# Altium schematic sheet [5] POWER.SchDoc("[5] POWER")
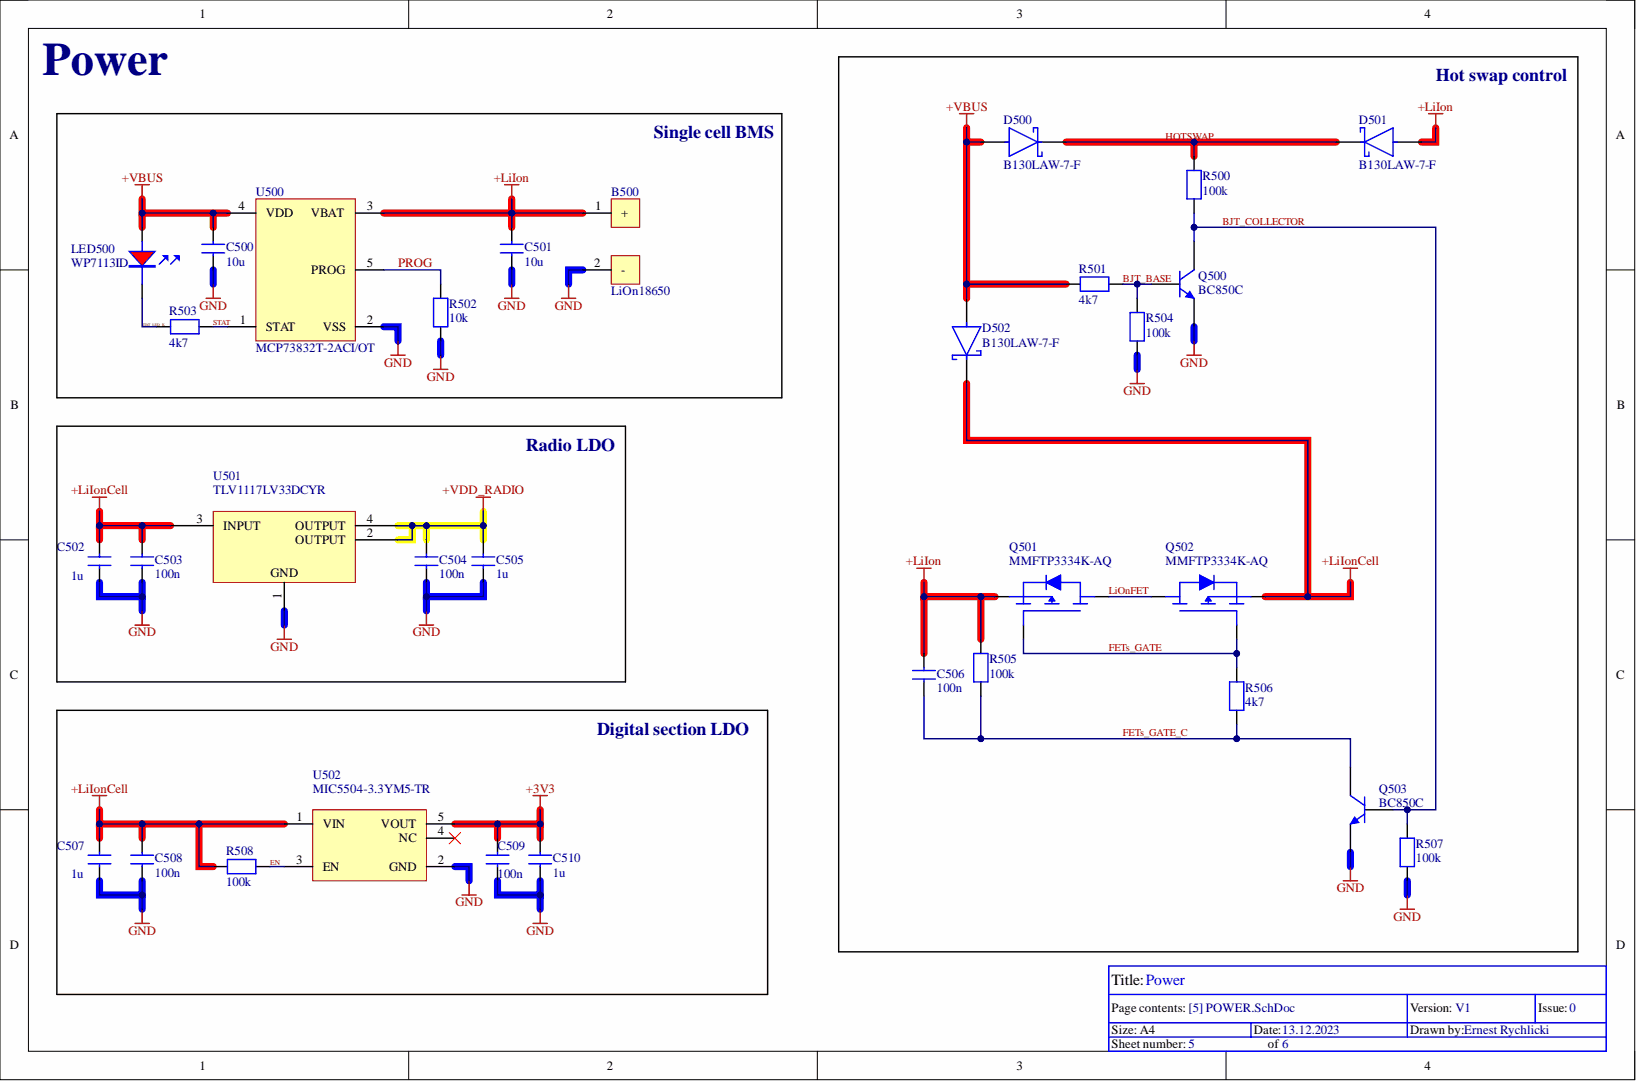
[diagram p0: [5] POWER.SchDoc]

## Parts
B500  LiOn18650  [LION18650]  (no BOM)
C500  10u  [C0402]
C501  10u  [C0402]
C502  1u  [C0402]
C503  100n  [C0402]
C504  100n  [C0402]
C505  1u  [C0402]
C506  100n  [C0402]
C507  1u  [C0402]
C508  100n  [C0402]
C509  100n  [C0402]
C510  1u  [C0402]
D500  B130LAW-7-F  [SOD-123]
D501  B130LAW-7-F  [SOD-123]
D502  B130LAW-7-F  [SOD-123]
LED500  WP7113ID  [LED5mm]
Q500  BC850C  [SOT23]
Q501  MMFTP3334K-AQ  [SOT23]
Q502  MMFTP3334K-AQ  [SOT23]
Q503  BC850C  [SOT23]
R500  100k  [R0603]
R501  4k7  [R0603]
R502  10k  [R0603]
R503  4k7  [R0603]
R504  100k  [R0603]
R505  100k  [R0603]
R506  4k7  [R0603]
R507  100k  [R0603]
R508  100k  [R0603]
U500  MCP73832T-2ACI/OT  [SOT23-5]
U501  TLV1117LV33DCYR  [SOT223-4]
U502  MIC5504-3.3YM5-TR  [SOT23-5]

## Nets
+3V3: C509.2 C510.2 U502.5(VOUT)
+LiIon: B500.1 C501.2 C506.2 D501.2 Q501.2 R505.2 U500.3(VBAT)
+LiIonCell: C502.2 C503.2 C507.2 C508.2 D502.1 Q502.2 R508.2 U501.3(INPUT) U502.1(VIN)
+VBUS: C500.2 D500.2 D502.2 LED500.A R501.2 U500.4(VDD)
+VDD_RADIO: C504.2 C505.2 U501.2(OUTPUT) U501.4(OUTPUT)
GND: B500.2 C500.1 C501.1 C502.1 C503.1 C504.1 C505.1 C507.1 C508.1 C509.1 C510.1 Q500.2 Q503.2 R502.1 R504.1 R507.1 U500.2(VSS) U501.1 U502.2(GND)
BJT_BASE: Q500.1 R501.1 R504.2
BJT_COLLECTOR: Q500.3 Q503.1 R500.1 R507.2
EN: R508.1 U502.3(EN)
FETs_GATE: Q501.1 Q502.1 R506.1
FETs_GATE_C: C506.1 Q503.3 R505.1 R506.2
HOTSWAP: D500.1 D501.1 R500.2
LiOnFET: Q501.3 Q502.3
PROG: R502.2 U500.5(PROG)
STAT: R503.1 U500.1(STAT)
STAT_LED_K: LED500.K R503.2

Not connected: U502 4(NC)

## Library data of the parts
B500 = LiOn18650: LiOn18650 - single cell battery, soldered to PCB; Library Name: ER_SCH_LIB.SchLib
C500 C501 = GRJ155R60J106ME11D: Capacitor Ceramic Mlcc 0402 10UF 6.3V SMD; Manufacturer: Murata; Tolerance: 20%; Case Code (Imperial): 0402; Case Code (Metric): 1005; Case/Package: 0402; Category: Ceramic Capacitors; Component Kind: Standard; ComponentLink1Description: https://datasheet.octopart.com/GRJ155R60J106ME11D-Murata-datasheet-149522788.pdf?src-supplier=Newark; ComponentLink1URL: https://datasheet.octopart.com/GRJ155R60J106ME11D-Murata-datasheet-149522788.pdf?src-supplier=Newark; ComponentLink2Description: http://psearch.en.murata.com/capacitor/product/GRJ155R60J106ME11%23.pdf?src-supplier=Digi-Key; ComponentLink2URL: http://psearch.en.murata.com/capacitor/product/GRJ155R60J106ME11%23.pdf?src-supplier=Digi-Key; ComponentLink3Description: https://datasheet.octopart.com/GRJ155R60J106ME11D-Murata-datasheet-165579666.pdf?src-supplier=TME; ComponentLink3URL: https://datasheet.octopart.com/GRJ155R60J106ME11D-Murata-datasheet-165579666.pdf?src-supplier=TME; Features: Soft Termination; Length: 0.039inch; Library Name: ER_SCH_LIB.SchLib; Manufacturer Part Number: GRJ155R60J106ME11D; Max Operating Temperature: 85°C; Min Operating Temperature: -55°C; Mounting Technology: Surface Mount; Packaging: Cut Tape; Pricing: 10000=0,0311, 20000=0,0295, 30000=0,0278, 50000=0,0262, 100000=0,0221, 250000=0,0204 (USD); RoHS: Yes; RoHS Compliant: Yes; Series: GRJ; Stock: 10000; Supplier: Arrow Electronics; Supplier Part Number: GRJ155R60J106ME11D; Thickness: 0.028inch; Value: 10uF; Voltage Rating: 6.3V; Width: 0.02inch
C502 C505 C507 C510 = 0402X105K100CT: Multilayer Ceramic Capacitor, 1 uF, 10%, X5r, 10 V, 0402 [1005 Metric]; Manufacturer: Walsin Technologies; Category: Ceramic Capacitors; Component Kind: Standard; ComponentLink1Description: http://www.farnell.com/datasheets/2340522.pdf?src-supplier=Farnell; ComponentLink1URL: http://www.farnell.com/datasheets/2340522.pdf?src-supplier=Farnell; ComponentLink2Description: http://www.farnell.com/datasheets/1958513.pdf?src-supplier=Farnell; ComponentLink2URL: http://www.farnell.com/datasheets/1958513.pdf?src-supplier=Farnell; ComponentLink3Description: http://www.farnell.com/datasheets/1958513.pdf?src-supplier=Element14; ComponentLink3URL: http://www.farnell.com/datasheets/1958513.pdf?src-supplier=Element14; ComponentLink4Description: https://datasheet.octopart.com/0402X105K100CT-Walsin-Technologies-datasheet-128065749.pdf?src-supplier=Future+Electronics; ComponentLink4URL: https://datasheet.octopart.com/0402X105K100CT-Walsin-Technologies-datasheet-128065749.pdf?src-supplier=Future+Electronics; ComponentLink5Description: https://datasheet.octopart.com/0402X105K100CT-Walsin-Technologies-datasheet-62066062.pdf?src-supplier=TME; ComponentLink5URL: https://datasheet.octopart.com/0402X105K100CT-Walsin-Technologies-datasheet-62066062.pdf?src-supplier=TME; ComponentLink6Description: https://datasheet.octopart.com/0402X105K100CT-Walsin-Technologies-datasheet-136037192.pdf?src-supplier=TECHDesign; ComponentLink6URL: https://datasheet.octopart.com/0402X105K100CT-Walsin-Technologies-datasheet-136037192.pdf?src-supplier=TECHDesign; Library Name: ER_SCH_LIB.SchLib; Manufacturer Part Number: 0402X105K100CT; Pricing: 10000=0,0037, 20000=0,0027, 50000=0,0026, 100000=0,0025, 250000=0,0023 (USD); RoHS: Yes; Stock: 760000; Supplier: Arrow Electronics; Supplier Part Number: 0402X105K100CT
C503 C504 C506 C508 C509 = 04023C104KAT2A: Multilayer Ceramic Capacitor, 0.1 uF, 25 V, ± 10%, X7R, 0402 [1005 Metric]; Manufacturer: Kyocera AVX; Material: 4241ConductorMaterial; Value: 100nF; Voltage: 25V; Case Code (Imperial): 0402; Case Code (Metric): 1005; Case/Package: 0402; Category: Ceramic Capacitors; Component Kind: Standard; ComponentLink1Description: https://datasheet.ciiva.com/6265/cx7r-6265272.pdf?src-supplier=Digi-Key; ComponentLink1URL: https://datasheet.ciiva.com/6265/cx7r-6265272.pdf?src-supplier=Digi-Key; ComponentLink2Description: https://datasheet.ciiva.com/1123/1679506-1123344.pdf?src-supplier=Element14; ComponentLink2URL: https://datasheet.ciiva.com/1123/1679506-1123344.pdf?src-supplier=Element14; ComponentLink3Description: https://datasheet.ciiva.com/7709/1679506-7709527.pdf?src-supplier=Element14; ComponentLink3URL: https://datasheet.ciiva.com/7709/1679506-7709527.pdf?src-supplier=Element14; ComponentLink4Description: https://datasheet.ciiva.com/14906/cx7r-14906223.pdf?src-supplier=Digi-Key; ComponentLink4URL: https://datasheet.ciiva.com/14906/cx7r-14906223.pdf?src-supplier=Digi-Key; ComponentLink5Description: https://datasheet.ciiva.com/7614/cx7r-7614663.pdf?src-supplier=Digi-Key; ComponentLink5URL: https://datasheet.ciiva.com/7614/cx7r-7614663.pdf?src-supplier=Digi-Key; Dielectric: X7R; Lead Free: Lead Free; Library Name: ER_SCH_LIB.SchLib; Manufacturer Part Number: 04023C104KAT2A; Max Operating Temperature: 125°C; Min Operating Temperature: -55°C; Mounting Technology: Surface Mount; Packaging: Cut Tape; Pins: 2; Pricing: 10000=0,0064, 20000=0,0061, 30000=0,0058, 50000=0,0052, 100000=0,0042, 150000=0,0038 (USD); Reach SVHC Compliant: No; RoHS: Yes; RoHS Compliant: Yes; Series: -; Stock: 5700000; Supplier: Arrow Electronics; Supplier Part Number: 04023C104KAT2A; Tolerance: 10%; Voltage Rating: 25V; Voltage Rating (DC): 25V; Width: 1mm
D500-D502 = B130LAW-7-F: Rectifier Diode Schottky 30V 1A 2-Pin SOD-123 T/R / DIODE SCHOTTKY 30V 1A SOD123; Manufacturer: Diodes; Forward Voltage: 420mV; Max Forward Surge Current (Ifsm): 12A; Max Reverse Current: 1mA; Polarity: Standard; Value: 40pF; Average Rectified Current: 1A; Category: Rectifier Diodes; Component Kind: Standard; ComponentLink1Description: https://datasheet.ciiva.com/913/ds30308-913553.pdf?src-supplier=Digi-Key; ComponentLink1URL: https://datasheet.ciiva.com/913/ds30308-913553.pdf?src-supplier=Digi-Key; ComponentLink2Description: https://datasheet.ciiva.com/34302/1538689-34302354.pdf?src-supplier=Jameco; ComponentLink2URL: https://datasheet.ciiva.com/34302/1538689-34302354.pdf?src-supplier=Jameco; ComponentLink3Description: https://datasheet.ciiva.com/4715/ds30308-79347-4715540.pdf?src-supplier=Mouser; ComponentLink3URL: https://datasheet.ciiva.com/4715/ds30308-79347-4715540.pdf?src-supplier=Mouser; ComponentLink4Description: https://datasheet.ciiva.com/1177/ds30308-1177411.pdf?src-supplier=Element14; ComponentLink4URL: https://datasheet.ciiva.com/1177/ds30308-1177411.pdf?src-supplier=Element14; ComponentLink5Description: https://datasheet.ciiva.com/22695/887879-22695783.pdf?src-supplier=Element14; ComponentLink5URL: https://datasheet.ciiva.com/22695/887879-22695783.pdf?src-supplier=Element14; ComponentLink6Description: https://datasheet.ciiva.com/8300/ds30308-8300503.pdf?src-supplier=Avnet; ComponentLink6URL: https://datasheet.ciiva.com/8300/ds30308-8300503.pdf?src-supplier=Avnet; ComponentLink7Description: https://datasheet.ciiva.com/8303/ds30308-8303245.pdf?src-supplier=Avnet; ComponentLink7URL: https://datasheet.ciiva.com/8303/ds30308-8303245.pdf?src-supplier=Avnet; ComponentLink8Description: https://datasheet.ciiva.com/913/ds30308-913554.pdf?src-supplier=Digi-Key; ComponentLink8URL: https://datasheet.ciiva.com/913/ds30308-913554.pdf?src-supplier=Digi-Key; ComponentLink9Description: https://datasheet.ciiva.com/913/ds30308-913555.pdf?src-supplier=Digi-Key; ComponentLink9URL: https://datasheet.ciiva.com/913/ds30308-913555.pdf?src-supplier=Digi-Key; Contact Plating: Tin,Matte; Current Rating: 1A; Forward Current: 1A; Height: 1.25mm; Lead Free: Lead Free; Length: 2.85mm; Library Name: ER_SCH_LIB.SchLib; Manufacturer Part Number: B130LAW-7-F; Max Operating Temperature: 125°C; Max Repetitive Reverse Voltage (Vrrm): 30V; Max Reverse Voltage (DC): 30V; Min Operating Temperature: -55°C; Mounting Technology: Surface Mount; Output Current: 1A; Package Quantity: 3000; Packaging: Tape and Reel; Peak Non-Repetitive Surge Current: 12A; Pins: 2; Pricing: 3000=0,0709 (USD); Radiation Hardening: No; Reach SVHC Compliant: No; Reverse Voltage: 30V; RoHS: Yes; RoHS Compliant: Yes; Series: -; Stock: 93000; Supplier: Arrow Electronics; Supplier Part Number: B130LAW-7-F; Termination: SMD/SMT; Voltage Rating (DC): 30V; Weight: 0.000353oz; Width: 1.7mm
LED500 = WP7113ID: Red T-1 3/4 5 mm 30° 50 mcd 2.2 V Tinted Solid State LED Lamp Through Hole; Manufacturer: Kingbright; Category: LEDs; Component Kind: Standard; ComponentLink1Description: https://datasheet.ciiva.com/2114/wp7113id-2114384.pdf?src-supplier=Digi-Key; ComponentLink1URL: https://datasheet.ciiva.com/2114/wp7113id-2114384.pdf?src-supplier=Digi-Key; ComponentLink2Description: https://datasheet.ciiva.com/4692/wp7113id-48891-4692862.pdf?src-supplier=Mouser; ComponentLink2URL: https://datasheet.ciiva.com/4692/wp7113id-48891-4692862.pdf?src-supplier=Mouser; ComponentLink3Description: https://datasheet.ciiva.com/16210/wp7113id-16210118.pdf?src-supplier=Future+Electronics; ComponentLink3URL: https://datasheet.ciiva.com/16210/wp7113id-16210118.pdf?src-supplier=Future+Electronics; Library Name: ER_SCH_LIB.SchLib; Manufacturer Part Number: WP7113ID; Pricing: 1=0,1, 10=0,072, 50=0,072, 100=0,072, 1000=0,068, 10000=0,06 (USD); RoHS: Yes; Stock: 35900; Supplier: Mouser; Supplier Part Number: 604-WP7113ID
Q500 Q503 = BC850C: Trans GP BJT NPN 45V 0.1A 250mW Automotive 3-Pin SOT-23 T/R; Manufacturer: Diotec; Category: BJTs; Component Kind: Standard; ComponentLink1Description: http://datasheet.octopart.com/BC850C-Diotec-datasheet-17564964.pdf?src-supplier=Verical; ComponentLink1URL: http://datasheet.octopart.com/BC850C-Diotec-datasheet-17564964.pdf?src-supplier=Verical; ComponentLink2Description: http://datasheet.octopart.com/BC850C-Diotec-datasheet-17564964.pdf?src-supplier=Mouser; ComponentLink2URL: http://datasheet.octopart.com/BC850C-Diotec-datasheet-17564964.pdf?src-supplier=Mouser; ComponentLink3Description: http://datasheet.octopart.com/BC850C-Diotec-datasheet-17564964.pdf?src-supplier=Distrelec; ComponentLink3URL: http://datasheet.octopart.com/BC850C-Diotec-datasheet-17564964.pdf?src-supplier=Distrelec; ComponentLink4Description: http://datasheet.octopart.com/BC850C-Diotec-datasheet-17564964.pdf?src-supplier=Onlinecomponents.com; ComponentLink4URL: http://datasheet.octopart.com/BC850C-Diotec-datasheet-17564964.pdf?src-supplier=Onlinecomponents.com; ComponentLink5Description: https://datasheet.octopart.com/BC850C-Diotec-datasheet-149503847.pdf?src-supplier=TME; ComponentLink5URL: https://datasheet.octopart.com/BC850C-Diotec-datasheet-149503847.pdf?src-supplier=TME; ComponentLink6Description: http://datasheet.octopart.com/BC850C-Diotec-datasheet-17564964.pdf?src-supplier=DComponents; ComponentLink6URL: http://datasheet.octopart.com/BC850C-Diotec-datasheet-17564964.pdf?src-supplier=DComponents; ComponentLink7Description: http://datasheet.octopart.com/BC850C-Diotec-datasheet-17564964.pdf?src-supplier=Electro+Sonic; ComponentLink7URL: http://datasheet.octopart.com/BC850C-Diotec-datasheet-17564964.pdf?src-supplier=Electro+Sonic; Library Name: ER_SCH_LIB.SchLib; Manufacturer Part Number: BC850C; Pricing: 1=0,18, 10=0,105, 50=0,105, 100=0,076, 1000=0,053, 10000=0,025 (USD); RoHS: Yes; Stock: 12088; Supplier: Mouser; Supplier Part Number: 637-BC850C
Q501 Q502 = MMFTP3334K-AQ: Mosfet, SOT-23, 30V, 4A, P, 1W; Manufacturer: Diotec; Category: Uncategorized; Component Kind: Standard; Library Name: ER_SCH_LIB.SchLib; Manufacturer Part Number: MMFTP3334K-AQ; Pricing: 1=0,6, 10=0,51, 50=0,51, 100=0,401, 1000=0,32, 10000=0,126 (USD); RoHS: No; Supplier: Mouser; Supplier Part Number: 637-MMFTP3334K-AQ
R500 R504 R505 R507 R508 = CRCW0603100KFKEBC: CRCW Thick Film Commodity Chip Resistor 0603 Size 100K Ohm 1% 0.1 W 100 ppm/K 2-Pin SMD Paper T/R; Manufacturer: Vishay; Max Power Dissipation: 100mW; Temperature Coefficient: 100ppm/°C; Value: 100kR; Case/Package: 0603; Category: Chip SMD Resistors; Component Kind: Standard; ComponentLink1Description: http://www.vishay.com/docs/28773/crcwce3.pdf?src-supplier=Digi-Key; ComponentLink1URL: http://www.vishay.com/docs/28773/crcwce3.pdf?src-supplier=Digi-Key; Lead Free: 5697LeadFree; Library Name: ER_SCH_LIB.SchLib; Manufacturer Part Number: CRCW0603100KFKEBC; Max Operating Temperature: 155°C; Min Operating Temperature: -55°C; Number of Terminations: 2; Packaging: Tape and Reel; Pricing: 1=0,1, 10=0,018, 50=0,018, 100=0,008, 1000=0,006, 10000=0,003 (USD); RoHS: Yes; RoHS Compliant: Yes; Series: CRCW-C; Stock: 86866; Supplier: Mouser; Supplier Part Number: 71-CRCW0603100KFKEBC; Tolerance: 1%
R501 R503 R506 = AF0603FR-074K7L: Res Thick Film 0603 4.7K Ohm 1% 1/10W ±100ppm/°C Molded SMD Paper T/R; Manufacturer: Yageo; Category: Chip SMD Resistors; Component Kind: Standard; ComponentLink1Description: http://www.mouser.com/ds/2/447/PYu-AF_51_RoHS_L_5-1085711.pdf?src-supplier=Mouser; ComponentLink1URL: http://www.mouser.com/ds/2/447/PYu-AF_51_RoHS_L_5-1085711.pdf?src-supplier=Mouser; ComponentLink2Description: https://datasheet.octopart.com/AF0603FR-074K7L-Yageo-datasheet-164730775.pdf?src-supplier=Future+Electronics; ComponentLink2URL: https://datasheet.octopart.com/AF0603FR-074K7L-Yageo-datasheet-164730775.pdf?src-supplier=Future+Electronics; ComponentLink3Description: http://www.yageo.com/documents/recent/PYu-AF_51_RoHS_L_5.pdf?src-supplier=Digi-Key; ComponentLink3URL: http://www.yageo.com/documents/recent/PYu-AF_51_RoHS_L_5.pdf?src-supplier=Digi-Key; Library Name: ER_SCH_LIB.SchLib; Manufacturer Part Number: AF0603FR-074K7L; Pricing: 1=0,0339, 10=0,0163, 25=0,0156, 100=0,0114 (USD); RoHS: Yes; Stock: 178; Supplier: Arrow Electronics; Supplier Part Number: AF0603FR-074K7L
R502 = AC0603JR-0710KL: SMD Chip Resistor, 10 kOhm, ± 5%, 100 mW, 0603 [1608 Metric], Thick Film, General Purpose; Manufacturer: Yageo; Max Power Dissipation: 100mW; Temperature Coefficient: 100ppm/°C; Value: 10kR; Voltage Rating: 50V; Case Code (Imperial): 0603; Case Code (Metric): 1608; Case/Package: 0603; Category: Chip SMD Resistors; Component Kind: Standard; ComponentLink1Description: https://datasheet.ciiva.com/5562/pyu-ac-51-rohs-l-2-5562553.pdf?src-supplier=Mouser; ComponentLink1URL: https://datasheet.ciiva.com/5562/pyu-ac-51-rohs-l-2-5562553.pdf?src-supplier=Mouser; Features: Moisture Resistant,Automotive AEC-Q200; Lead Free: Lead Free; Library Name: ER_SCH_LIB.SchLib; Manufacturer Part Number: AC0603JR-0710KL; Max Operating Temperature: 155°C; Min Operating Temperature: -55°C; Number of Terminations: 2; Packaging: Tape and Reel; Power Rating: 100mW; Pricing: 1=0,0527, 10=0,012, 25=0,0107, 100=0,007, 250=0,0069, 500=0,0062 (USD); Ratings: AEC-Q200; RoHS: Yes; RoHS Compliant: Yes; Series: AC; Stock: 801; Supplier: Arrow Electronics; Supplier Part Number: AC0603JR-0710KL; Termination: SMD/SMT; Tolerance: 5%
U500 = MCP73832T-2ACI/OT: MCP73832 Series Single-Cell Li-Ion/Li-Polymer Battery Charge Controller SOT-23-5; Manufacturer: Microchip; Category: Battery Management; Component Kind: Standard; ComponentLink1Description: https://datasheet.ciiva.com/1931/21984e-1931959.pdf?src-supplier=Digi-Key; ComponentLink1URL: https://datasheet.ciiva.com/1931/21984e-1931959.pdf?src-supplier=Digi-Key; ComponentLink2Description: https://datasheet.ciiva.com/1931/21984e-1931960.pdf?src-supplier=Digi-Key; ComponentLink2URL: https://datasheet.ciiva.com/1931/21984e-1931960.pdf?src-supplier=Digi-Key; ComponentLink3Description: https://datasheet.ciiva.com/1931/21984e-1931961.pdf?src-supplier=Digi-Key; ComponentLink3URL: https://datasheet.ciiva.com/1931/21984e-1931961.pdf?src-supplier=Digi-Key; Library Name: ER_SCH_LIB.SchLib; Manufacturer Part Number: MCP73832T-2ACI/OT; Pricing: 1=0,7211, 10=0,7209, 25=0,7119, 100=0,7029, 250=0,6938, 500=0,6848, 1000=0,6758, 3000=0,6668, 6000=0,6578 (USD); RoHS: Yes; Stock: 8708; Supplier: Arrow Electronics; Supplier Part Number: MCP73832T-2ACI/OT
U501 = TLV1117LV33DCYR: IC REG LDO 3.3V 1A SOT223; Manufacturer: Texas Instruments; Category: Voltage Regulators - Linear; Component Kind: Standard; ComponentLink1Description: https://datasheet.ciiva.com/2060/tlv1117lv-2060226.pdf?src-supplier=Digi-Key; ComponentLink1URL: https://datasheet.ciiva.com/2060/tlv1117lv-2060226.pdf?src-supplier=Digi-Key; ComponentLink2Description: https://datasheet.ciiva.com/2060/tlv1117lv-2060227.pdf?src-supplier=Digi-Key; ComponentLink2URL: https://datasheet.ciiva.com/2060/tlv1117lv-2060227.pdf?src-supplier=Digi-Key; ComponentLink3Description: https://datasheet.ciiva.com/2060/tlv1117lv-2060228.pdf?src-supplier=Digi-Key; ComponentLink3URL: https://datasheet.ciiva.com/2060/tlv1117lv-2060228.pdf?src-supplier=Digi-Key; ComponentLink4Description: https://datasheet.ciiva.com/46443/1857581-46443437.pdf?src-supplier=Element14; ComponentLink4URL: https://datasheet.ciiva.com/46443/1857581-46443437.pdf?src-supplier=Element14; ComponentLink5Description: https://datasheet.ciiva.com/46443/1857581-46443438.pdf?src-supplier=Element14; ComponentLink5URL: https://datasheet.ciiva.com/46443/1857581-46443438.pdf?src-supplier=Element14; ComponentLink6Description: https://datasheet.ciiva.com/46443/1857581-46443450.pdf?src-supplier=Element14; ComponentLink6URL: https://datasheet.ciiva.com/46443/1857581-46443450.pdf?src-supplier=Element14; Library Name: ER_SCH_LIB.SchLib; Manufacturer Part Number: TLV1117LV33DCYR; Pricing: 1=0,35, 10=0,261, 50=0,261, 100=0,146, 1000=0,108, 10000=0,087 (USD); RoHS: Yes; Stock: 41813; Supplier: Mouser; Supplier Part Number: 595-TLV1117LV33DCYR
U502 = MIC5504-3.3YM5-TR: LDO Regulator Pos 3.3V 0.3A Medical 5-Pin SOT-23 T/R / IC REG LDO 3.3V 0.3A SOT-23-5; Manufacturer: Microchip; Category: Voltage Regulators - Linear; Component Kind: Standard; ComponentLink1Description: https://datasheet.ciiva.com/46425/1856860-46425639.pdf?src-supplier=Element14; ComponentLink1URL: https://datasheet.ciiva.com/46425/1856860-46425639.pdf?src-supplier=Element14; Library Name: ER_SCH_LIB.SchLib; Manufacturer Part Number: MIC5504-3.3YM5-TR; Pricing: 3000=0,1186 (USD); RoHS: Yes; Stock: 27000; Supplier: Arrow Electronics; Supplier Part Number: MIC5504-3.3YM5-TR
